# Altium schematic sheet ESP32.SchDoc(ESP32)
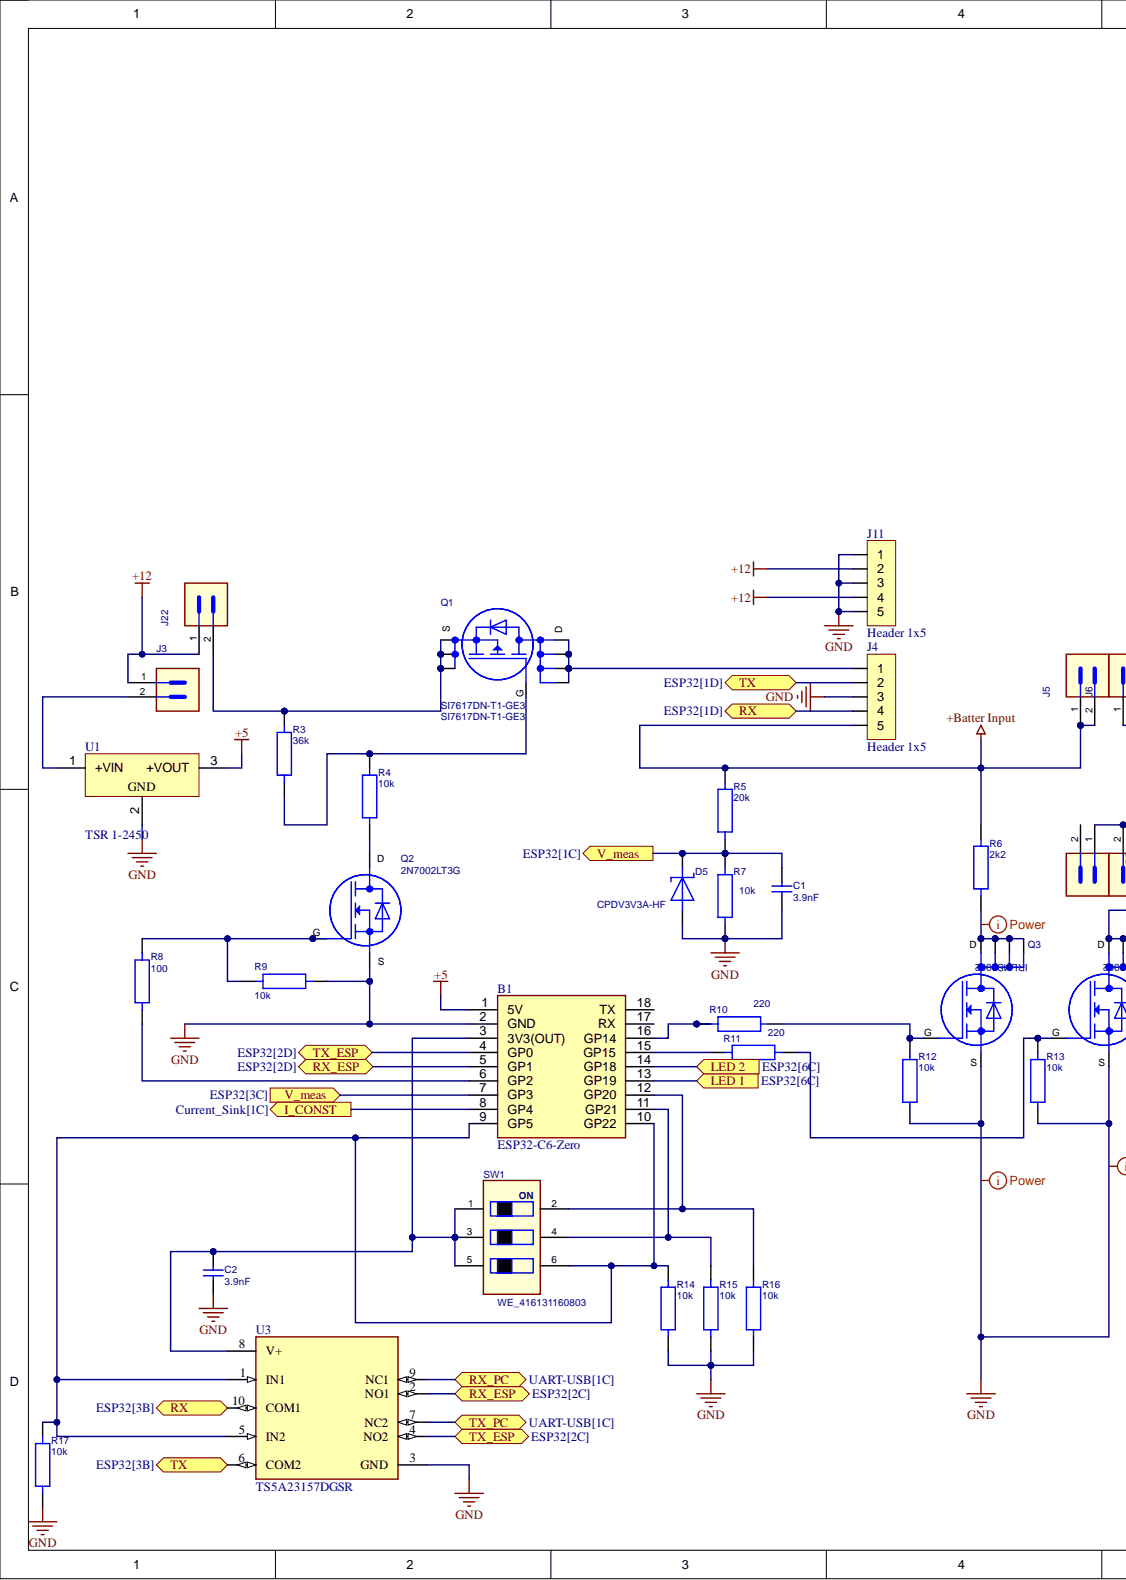
[diagram p0: ESP32.SchDoc, left]
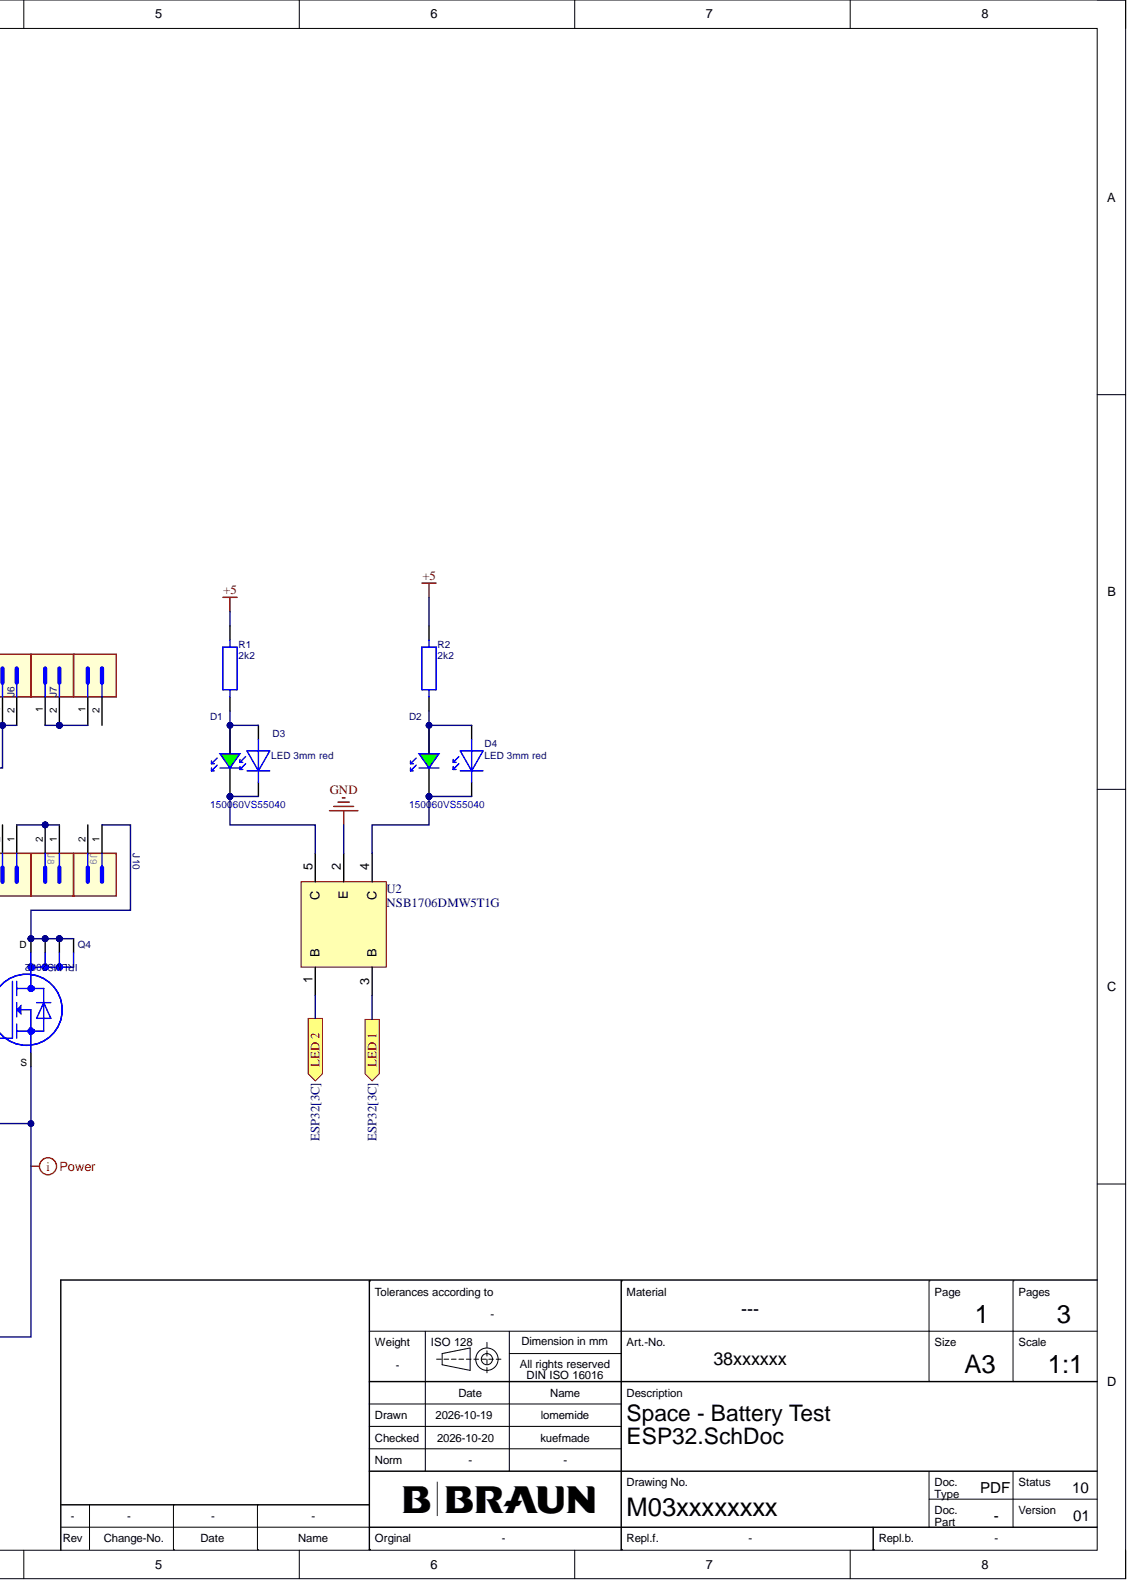
[diagram p1: ESP32.SchDoc, right]

## Parts
B1  ESP32-C6-Zero  [ESP32C6Zero]
C1  3.9nF  [CAP0805H1520]
C2  3.9nF  [CAP0805H1520]
D1  150060VS55040  [LEDC160X75X41L40-green(WE)]
D2  150060VS55040  [LEDC160X75X41L40-green(WE)]
D3  LED 3mm red  Category: LED  Package / Case: 3mm  [LED_THT_3mm]
D4  LED 3mm red  Category: LED  Package / Case: 3mm  [LED_THT_3mm]
D5  CPDV3V3A-HF  [SOD882]
J3  5-825433-0_2/50  [PIN_HEADER_2_54mm_1_1mm_1x2]  (value not drawn)
J4  Header 1x5  [Header 1x5 2.54mm]
J5  5-825433-0_2/50  [PIN_HEADER_2_54mm_1_1mm_1x2]  (value not drawn)
J6  5-825433-0_2/50  [PIN_HEADER_2_54mm_1_1mm_1x2]  (value not drawn)
J7  5-825433-0_2/50  [PIN_HEADER_2_54mm_1_1mm_1x2]  (value not drawn)
J8  5-825433-0_2/50  [PIN_HEADER_2_54mm_1_1mm_1x2]  (value not drawn)
J9  5-825433-0_2/50  [PIN_HEADER_2_54mm_1_1mm_1x2]  (value not drawn)
J10  5-825433-0_2/50  [PIN_HEADER_2_54mm_1_1mm_1x2]  (value not drawn)
J11  Header 1x5  [Header 1x5 2.54mm]
J22  5-825433-0_2/50  [PIN_HEADER_2_54mm_1_1mm_1x2]  (value not drawn)
Q1  SI7617DN-T1-GE3  [PowerPAK1212-8]
Q2  2N7002LT3G  [SOT23]
Q3  IRLMS2002  [SOT23-6]
Q4  IRLMS2002  [SOT23-6]
R1  2k2  [RES0805MD]
R2  2k2  [RES0805MD]
R3  36k  [RES0805MD]
R4  10k  [RES0805MD]
R5  20k  [RES0805MD]
R6  2k2  [RES0805MD]
R7  10k  [RES0805MD]
R8  100  [RES0805MD]
R9  10k  [RES0805MD]
R10  220  [RES0805MD]
R11  220  [RES0805MD]
R12  10k  [RES0805MD]
R13  10k  [RES0805MD]
R14  10k  [RES0805MD]
R15  10k  [RES0805MD]
R16  10k  [RES0805MD]
R17  10k  [RES0805MD]
SW1  WE_416131160803  [WE_416131160803]
U1  TSR 1-2450  [TRACO-SIP-3_V]
U2  NSB1706DMW5T1G  [ONSC-SC70-5-419A-02_V]
U3  TS5A23157DGSR  [FP-DGS0010A-IPC_C]

## Ports
I_CONST, LED 1, LED 2, RX, RX_ESP, RX_PC, TX, TX_ESP, TX_PC, V_meas

## Nets
+5: B1.1(5V) R1.2 R2.2 U1.3
+12: J3.1 J11.2 J11.4 J22.1
+Batter Input: J4.5 J5.1 J5.2 R5.2 R6.1
GND: B1.2(GND) C1.1 C2.1 D5.2 J4.3 J11.1 J11.3 J11.5 Q2.2 Q3.4 Q4.4 R7.1 R9.1 R12.1 R13.1 R14.2 R15.2 R16.2 R17.2 U1.2 U2.2(E) U3.3(GND)
I_CONST: B1.8(GP4) ; port I_CONST
LED 1: B1.13(GP19) U2.3(B) ; port LED 1
LED 2: B1.14(GP18) U2.1(B) ; port LED 2
RX: J4.4 U3.10(COM1) ; port RX
RX_ESP: B1.5(GP1) U3.2(NO1) ; port RX_ESP
RX_PC: U3.9(NC1) ; port RX_PC
TX: J4.2 U3.6(COM2) ; port TX
TX_ESP: B1.4(GP0) U3.4(NO2) ; port TX_ESP
TX_PC: U3.7(NC2) ; port TX_PC
V_meas: B1.7(GP3) C1.2 D5.1 R5.1 R7.2 ; port V_meas
NetB1_3: B1.3(3V3(OUT)) C2.2 SW1.1 SW1.3 SW1.5 U3.8(V+)
NetB1_6: B1.6(GP2) R8.1
NetB1_9: B1.9(GP5) B1.10(GP22) R14.1 R17.1 SW1.6 U3.1(IN1) U3.5(IN2)
NetB1_11: B1.11(GP21) R15.1 SW1.4
NetB1_12: B1.12(GP20) R16.1 SW1.2
NetB1_15: B1.15(GP15) R11.1
NetB1_16: B1.16(GP14) R10.1
NetD1_1: D1.1 D3.2 U2.5(C)
NetD1_2: D1.2 D3.1 R1.1
NetD2_1: D2.1 D4.2 U2.4(C)
NetD2_2: D2.2 D4.1 R2.1
NetJ3_2: J3.2 U1.1
NetJ4_1: J4.1 Q1.5 Q1.6 Q1.7 Q1.8
NetJ6_1: J6.1 J6.2 J7.1
NetJ8_1: J8.1 J9.1 J9.2
NetJ10_1: J10.1 Q4.1 Q4.2 Q4.5 Q4.6
NetJ22_2: J22.2 Q1.1 Q1.2 Q1.3 R3.2
NetQ1_4: Q1.4 R3.1 R4.1
NetQ2_1: Q2.1 R8.2 R9.2
NetQ2_3: Q2.3 R4.2
NetQ3_1: Q3.1 Q3.2 Q3.5 Q3.6 R6.2
NetQ3_3: Q3.3 R10.2 R12.2
NetQ4_3: Q4.3 R11.2 R13.2

Not connected: B1 17(RX) 18(TX); J7 2; J8 2; J10 2

## Library data of the parts
B1 = ESP32-C6-Zero: ESP32-C6 Mini Development Board, Based on ESP32-C6FH4, Dual Processors, 160MHz Running Frequency, 2.4GHz WiFi 6 & Bluetooth 5, ESP32 Development Board; Manufacturer_Name: Waveshare; Geometry.Height: 5.5mm; Component Kind: Standard; Datasheet Link: https://www.waveshare.com/esp32-c6-zero.htm; Library Name: ESP32-C6-Zero.SchLib; Manufacturer_Part_Number: ESP32-C6-Zero
C1 C2 = UID-1DC189D77836E3ADB330: CAP 3.9nF 5% C0G 50V; Manufacturer: KYOCERA AVX; Manufacturer Part Number: 08055A392JAT2A; SAP-Partnumber: 35031280; Compliance: RoHS2; Database Table Name: Capacitors; Library Status: Production; Reviewer: hoersede; Temperature - Rated: -55 °C to +125 °C; Category: Ceramic; Component Kind: Standard; ComponentLink1Description: Datasheet; ComponentLink1URL: https://code.bbraun.io/BA-RD-EE/altium-partlib/raw/main/components/datasheets/35031280_SMD_KOND-KER_3,9NF_5Proz_50V_C0G_0805/AVX_datasheet_C0GNP0-Dielectric.pdf; ComponentLink2Description: Compliance Certificate; ComponentLink2URL: https://code.bbraun.io/BA-RD-EE/altium-partlib/raw/main/components/datasheets/35031280_SMD_KOND-KER_3,9NF_5Proz_50V_C0G_0805/AVX_datasheet_C0GNP0-Dielectric.pdf; ComponentLink3Description: Datasheet Folder; ComponentLink3URL: https://code.bbraun.io/BA-RD-EE/altium-partlib/raw/main/components/datasheets/35031280_SMD_KOND-KER_3,9NF_5Proz_50V_C0G_0805/; ComponentLink4Description: Data Sheet Library; ComponentLink4URL: http://ais.development.bbraun.com/dsl/35031280; Creation Date: 22.05.2023; Last Updated: 22.05.2023; Lifecycle Status: Active; Maximum Height: 1.52 mm; Package / Case: 0805; partid: 60; Price@1k: 0,01183 €; Review Date: 07.06.2025; Supplier 1: Mouser; Supplier 2: Digikey; Supplier 3: RS Components; Supplier 4: Farnell; Technology: SMT; Tolerance: 5%; Type: C0G; uid: UID-1DC189D77836E3ADB330; Value: 3.9nF; Voltage - Rated: 50V; Creator: weirmade; Library Name: BBM-partlib-official.DbLib
D1 D2 = UID-108CCBCADD5F52F6FAD1: Led Green 0603 SMD; Manufacturer: Würth Elektronik; SAP-Partnumber: 35146000; Compliance: RoHS; Current Rated: 20mA; Database Table Name: All; Library Status: Prototype; Remark: NGX; Reviewer: hoersede; Temperature - Rated: -40 °C to +85 °C; Voltage - Rated: 2.4V; Category: LED; Component Kind: Standard; ComponentLink1Description: Datasheet; ComponentLink1URL: https://code.bbraun.io/BA-RD-EE/altium-partlib/raw/main/components/datasheets/35146000_SMD_LED-Green_0603/35146000_Wuerth_150060VS55040_Datasheet.pdf; ComponentLink2Description: Compliance Certificate; ComponentLink2URL: https://code.bbraun.io/BA-RD-EE/altium-partlib/raw/main/components/datasheets/35146000_SMD_LED-Green_0603/35146000_Wuerth_150060VS55040_ROHS_REACH.pdf; ComponentLink3Description: Datasheet Folder; ComponentLink3URL: https://code.bbraun.io/BA-RD-EE/altium-partlib/raw/main/components/datasheets/35146000_SMD_LED-Green_0603/; ComponentLink4Description: Data Sheet Library; ComponentLink4URL: http://ais.development.bbraun.com/dsl/35146000; Creation Date: 21.05.2022; Last Updated: 15.01.2026; Lifecycle Status: Active; Manufacturer Part Number: 150060VS55040; Maximum Height: 0.5 mm; Package / Case: 0603; partid: 61; Price@1k: 0,033 €; Review Date: 08.06.2025; Supplier 1: Mouser; Supplier 2: Digikey; Supplier 3: RS Components; Supplier 4: Farnell; Supplier Part Number 1: 710-150060VS55040; Supplier Part Number 2: 732-12017-2-ND; Supplier Part Number 3: 1766157; Supplier Part Number 4: 2900765RL; Technology: SMT; uid: UID-108CCBCADD5F52F6FAD1; Creator: GEG DF,GK; Library Name: BBM-partlib-official.DbLib
D3 D4 = UID-E12BBC48C07D2EA352E9: LED Red, 625 nm, GaAsP/GaP, THT, 3mm; Manufacturer: TruComponents; Manufacturer Part Number: TC-9510472; Current Rated: 20 mA; Database Table Name: All; Library Status: New; Remark: EPG; Temperature - Rated: -40 °C to + 85 °C; Voltage - Rated: 5V; BBPUC-Partnumber: -; Component Kind: Standard; ComponentLink1Description: Datasheet; ComponentLink1URL: https://code.bbraun.io/BA-RD-EE/altium-partlib/raw/main/components/datasheets/UID-E12BBC48C07D2EA352E9_LED_3mm_Red_THT/3mm_LED_Datasheet.pdf; ComponentLink2Description: Compliance Certificate; ComponentLink3Description: Datasheet Folder; ComponentLink3URL: https://code.bbraun.io/BA-RD-EE/altium-partlib/raw/main/components/datasheets/UID-E12BBC48C07D2EA352E9_LED_3mm_Red_THT/; ComponentLink4Description: Data Sheet Library; Creation Date: 22.04.2026; Lifecycle Status: Active; Maximum Height: 6.3 mm; partid: 106; Price@1k: 0,18 €; Supplier 1: Conrad; Supplier 2: Digikey; Supplier 3: RS Components; Supplier 4: Farnell; Supplier Part Number 1: 2377618 - 62; Technology: THT; uid: UID-E12BBC48C07D2EA352E9; Creator: palmfrde; Library Name: BBM-partlib-official.DbLib
D5 = UID-3D03554C99A7E31313F8: Unidirectional ESD protection diode; BBPUC-Partnumber: 2838.332; Manufacturer: Nexperia; Manufacturer Part Number: PESD3V3S1UL; SAP-Partnumber: 35140169; Compliance: RoHS2; Current Rated: 15A; Database Table Name: All; Library Status: Prototype; Reviewer: hoersede; Temperature - Rated: -55 °C to +150 °C; Voltage - Rated: 3.3V; Category: ESD Diode; Component Kind: Standard; ComponentLink1Description: Datasheet; ComponentLink1URL: https://code.bbraun.io/BA-RD-EE/altium-partlib/raw/main/components/datasheets/35140169_SMD_Diode_ESD_PESD3V3S1UL_SOD882/PESD3V3S1UL.pdf; ComponentLink2Description: Compliance Certificate; ComponentLink2URL: https://code.bbraun.io/BA-RD-EE/altium-partlib/raw/main/components/datasheets/35140169_SMD_Diode_ESD_PESD3V3S1UL_SOD882/Nexperia_-_Statement_on_RoHS_082019.pdf; ComponentLink3Description: Datasheet Folder; ComponentLink3URL: https://code.bbraun.io/BA-RD-EE/altium-partlib/raw/main/components/datasheets/35140169_SMD_Diode_ESD_PESD3V3S1UL_SOD882/; ComponentLink4Description: Data Sheet Library; ComponentLink4URL: http://ais.development.bbraun.com/dsl/35140169; Creation Date: 16.05.2023; Last Updated: 22.06.2023; Lifecycle Status: Active; Maximum Height: 0.5 mm; Package / Case: SOD882; partid: 43; Price@1k: 0,0247 €; Review Date: 08.06.2025; Supplier 1: Mouser; Supplier 2: Digikey; Supplier 3: RS Components; Supplier 4: Farnell; uid: UID-3D03554C99A7E31313F8; Creator: hoersede; Library Name: BBM-partlib-official.DbLib
J3 J5-J10 J22 = UID-C948C1FBE4D127CA7C47: THT Pin Header, 1x2, 2.54mm, stehend; Manufacturer: TE Connectivity; SAP-Partnumber: 33193339; Compliance: RoHS2; Contacts: 2; Current Rated: 5A; Database Table Name: All; Library Status: Prototype; Reviewer: vaupmide; Temperature - Rated: -65 °C to + 105 °C; Voltage - Rated: 750Vrms; Category: Pin Header; Component Kind: Standard; ComponentLink1Description: Datasheet; ComponentLink1URL: https://code.bbraun.io/BA-RD-EE/altium-partlib/raw/main/components/datasheets/33193339_PIN_HEADER_2_54mm_1x50_TE/product-5-825433-0.datasheet.pdf; ComponentLink2Description: Compliance Certificate; ComponentLink2URL: https://code.bbraun.io/BA-RD-EE/altium-partlib/raw/main/components/datasheets/33193339_PIN_HEADER_2_54mm_1x50_TE/product-5-825433-0.datasheet.pdf; ComponentLink3Description: Datasheet Folder; ComponentLink3URL: https://code.bbraun.io/BA-RD-EE/altium-partlib/raw/main/components/datasheets/33193339_PIN_HEADER_2_54mm_1x50_TE; ComponentLink4Description: Data Sheet Library; ComponentLink4URL: http://ais.development.bbraun.com/dsl/33193339; Creation Date: 09.02.2023; Last Updated: 22.06.2023; Lifecycle Status: Active; Manufacturer Part Number: 5-825433-0; Maximum Height: 8.54 mm; partid: 27; Price@1k: 1,65 €; Review Date: 12.06.2024; Supplier 1: Mouser; Supplier 2: Digikey; Supplier 3: RS Components; Supplier 4: Farnell; uid: UID-C948C1FBE4D127CA7C47; Creator: sifngu01; Library Name: BBM-partlib-official.DbLib
J4 J11 = CMP-002-00275-1: Header 1x5 2.54mm; Component Kind: Standard; Design Item ID: Header 1x5; Source: Header.SchLib; Library Name: Discover Tour
Q1 = UID-FE97C754B092DB379145: FET P-CH; Manufacturer: Vishay; SAP-Partnumber: 35140024; Compliance: RoHS3; Current - Rated: 35 A; Database Table Name: Transistors; Library Status: Prototype; RDS-on: 0.0123R; Reviewer: vaupmide; Temperature - Rated: -55 °C to +150 °C; Voltage - Rated: 30V; Category: FET p-Ch; Component Kind: Standard; ComponentLink1Description: Datasheet; ComponentLink1URL: https://code.bbraun.io/BA-RD-EE/altium-partlib/raw/main/components/datasheets/35140024_SMD_Transistor_P-Channel_Si7617DN/Datasheet_SI7617DN.pdf; ComponentLink2Description: Compliance Certificate; ComponentLink2URL: https://code.bbraun.io/BA-RD-EE/altium-partlib/raw/main/components/datasheets/35140024_SMD_Transistor_P-Channel_Si7617DN/Compliance/VISH-E-A0015053004-1.pdf; ComponentLink3Description: Datasheet Folder; ComponentLink3URL: https://code.bbraun.io/BA-RD-EE/altium-partlib/raw/main/components/datasheets/35140024_SMD_Transistor_P-Channel_Si7617DN/; ComponentLink4Description: Data Sheet Library; ComponentLink4URL: http://ais.development.bbraun.com/dsl/35140024; Creation Date: 04.08.2023; Last Updated: 28.11.2023; Lifecycle Status: Active; Manufacturer Part Number: SI7617DN-T1-GE3; Maximum Height: 1.17 mm; Package / Case: PowerPAK1212-8; partid: 22; Price@1k: 0,2450 €; Review Date: 08.07.2024; Supplier 1: Mouser; Supplier 2: Digikey; Supplier 3: RS Components; Supplier 4: Farnell; Supplier Part Number 1: 781-SI7617DN-GE3; Supplier Part Number 2: SI7617DN-T1-GE3TR-ND; Technology: SMT; uid: UID-FE97C754B092DB379145; Creator: mochalde; Library Name: BBM-partlib-official.DbLib
Q2 = UID-746324AF67844B7863CB: N-Channel MOSFET 60V 115m, SMD SOT23-3; Manufacturer: onsemi; SAP-Partnumber: 35140038; Compliance: RoHS; Current - Rated: 115 mA; Database Table Name: Transistors; Library Status: Prototype; RDS-on: 7R5; Remark: NGX; Reviewer: vaupmide; Temperature - Rated: -55 °C to +150 °C; Category: FET n-Ch; Component Kind: Standard; ComponentLink1Description: Datasheet; ComponentLink1URL: https://code.bbraun.io/BA-RD-EE/altium-partlib/raw/main/components/datasheets/35140038_SMD_MOSFET-N-Chanel_2N7002L_SOT23/Onsemi_2N7002LT3G.PDF; ComponentLink2Description: Compliance Certificate; ComponentLink2URL: https://code.bbraun.io/BA-RD-EE/altium-partlib/raw/main/components/datasheets/35140038_SMD_MOSFET-N-Chanel_2N7002L_SOT23/Compliance/RoHS-Compliance_2N7002LT3G.png; ComponentLink3Description: Datasheet Folder; ComponentLink3URL: https://code.bbraun.io/BA-RD-EE/altium-partlib/raw/main/components/datasheets/35140038_SMD_MOSFET-N-Chanel_2N7002L_SOT23/; ComponentLink4Description: Data Sheet Library; ComponentLink4URL: http://ais.development.bbraun.com/dsl/35140038; Creation Date: 22.04.2022; Last Updated: 10.01.2024; Lifecycle Status: Active; Manufacturer Part Number: 2N7002LT3G; Maximum Height: 1.1 mm; Package / Case: SOT-23; partid: 17; Price@1k: 0,235 €; Review Date: 08.07.2024; Supplier 1: Mouser; Supplier 2: Digikey; Supplier 3: RS Components; Supplier 4: Farnell; Supplier Part Number 1: 863-2N7002LT3G; Supplier Part Number 2: 2N7002LT3GOSTR-ND; Technology: SMT; uid: UID-746324AF67844B7863CB; Voltage - Rated: 60V; Creator: GEG DF,GK; Library Name: BBM-partlib-official.DbLib
Q3 Q4 = UID-A18DAACDD2725A595700: FET N-Ch; Manufacturer: Infineon; Manufacturer Part Number: IRLMS2002TRPBF; SAP-Partnumber: 35140704; Compliance: RoHS2; Current Rated: 6.5A; Database Table Name: All; Library Status: Production; RDS-on: 0.03R; Reviewer: hoersede; Temperature - Rated: -55 °C to +150 °C; Voltage - Rated: 20V; Category: FET n-Ch; Component Kind: Standard; ComponentLink1Description: Datasheet; ComponentLink1URL: https://code.bbraun.io/BA-RD-EE/altium-partlib/raw/main/components/datasheets/35140704_SMD_TRANSISTOR_N-KANAL_IRLMS2002_SOT-23/Infineon_datasheet_IRLMS2002-v01_01.pdf; ComponentLink2Description: Compliance Certificate; ComponentLink2URL: https://code.bbraun.io/BA-RD-EE/altium-partlib/raw/main/components/datasheets/35140704_SMD_TRANSISTOR_N-KANAL_IRLMS2002_SOT-23/Infineon_datasheet_IRLMS2002-v01_01.pdf; ComponentLink3Description: Datasheet Folder; ComponentLink3URL: https://code.bbraun.io/BA-RD-EE/altium-partlib/raw/main/components/datasheets/35140704_SMD_TRANSISTOR_N-KANAL_IRLMS2002_SOT-23/; ComponentLink4Description: Data Sheet Library; ComponentLink4URL: http://ais.development.bbraun.com/dsl/35140704; Creation Date: 18.08.2022; Lifecycle Status: Active; Maximum Height: 1.45 mm; Package / Case: SOT23; partid: 7; Price@1k: 0,0751 €; Review Date: 07.06.2025; Supplier 1: Mouser; Supplier 2: Digikey; Supplier 3: RS Components; Supplier 4: Farnell; uid: UID-A18DAACDD2725A595700; Creator: weirmade; Library Name: BBM-partlib-official.DbLib
R1-R17 = UID-0F2DF2513351442B7CD8: RES 2K2 1% 125mW 0805; Manufacturer: Yageo; Manufacturer Part Number: RC0805FR-132K2L; SAP-Partnumber: 35110490; Compliance: RoHS; Database Table Name: All; Library Status: New; Remark: NGX; Reviewer: hoersede; Temperature - Rated: -55 °C to +155 °C; Temperature Coefficient: TC100; Voltage - Rated: 150V; Component Kind: Standard; ComponentLink1Description: Datasheet; ComponentLink1URL: https://code.bbraun.io/BA-RD-EE/altium-partlib/raw/main/components/datasheets/35110490_SMD_Widerstand_2K2_1Proz_0805/Yageo_RC0805FR-132K2L.pdf; ComponentLink2Description: Compliance Certificate; ComponentLink2URL: https://code.bbraun.io/BA-RD-EE/altium-partlib/raw/main/components/datasheets/35110490_SMD_Widerstand_2K2_1Proz_0805/Yageo_RC0805FR-132K2L.pdf; ComponentLink3Description: Datasheet Folder; ComponentLink3URL: https://code.bbraun.io/BA-RD-EE/altium-partlib/raw/main/components/datasheets/35110490_SMD_Widerstand_2K2_1Proz_0805/; ComponentLink4Description: Data Sheet Library; ComponentLink4URL: http://ais.development.bbraun.com/dsl/35110490; Creation Date: 22.04.2022; Last Updated: 22.06.2023; Lifecycle Status: Active; Maximum Height: 0.6 mm; Package / Case: 0805; partid: 156; Power - Rated: 125mW; Price@1k: 0,0075 €; Review Date: 10.03.2026; Supplier 1: Mouser; Supplier 2: Digikey; Supplier 3: RS Components; Supplier 4: Farnell; Supplier Part Number 1: 603-RC0805FR-132K2L; Supplier Part Number 2: 13-RC0805FR-132K2LTR-ND; Technology: SMT; Tolerance: 1%; Type: Thick Film; uid: UID-0F2DF2513351442B7CD8; Value: 2k2; Creator: GEG DF,GK; Library Name: BBM-partlib-official.DbLib
SW1 = UID-1051BDF3C131CE8C09EA: SMD DIP-Switch tripple 1.27mm pitch; Manufacturer: Würth Elektronik; Compliance: RoHS; Database Table Name: All; Temperature - Rated: -40 °C to +85 °C; Category: DIP Switch; Component Kind: Standard; ComponentLink1Description: Datasheet; ComponentLink1URL: https://code.bbraun.io/BA-RD-EE/altium-partlib/raw/main/components/datasheets/UID-1051BDF3C131CE8C09EA_DIP-Switch_Wuerth_416131160803/Wuerth_416131160803.pdf; ComponentLink2Description: Compliance Certificate; ComponentLink2URL: https://code.bbraun.io/BA-RD-EE/altium-partlib/raw/main/components/datasheets/UID-1051BDF3C131CE8C09EA_DIP-Switch_Wuerth_416131160803/Wuerth_416131160803.pdf; ComponentLink3Description: Datasheet Folder; ComponentLink3URL: https://code.bbraun.io/BA-RD-EE/altium-partlib/raw/main/components/datasheets/UID-1051BDF3C131CE8C09EA_DIP-Switch_Wuerth_416131160803/; ComponentLink4Description: Data Sheet Library; Creation Date: 11.02.2025; Manufacturer Part Number: 416131160803; Maximum Height: 2.65 mm; partid: 30; Price@1k: 1,76 €; Supplier 1: Mouser; Supplier 2: Digikey; Supplier 3: RS Components; Supplier 4: Farnell; Supplier Part Number 1: 710-416131160803; Supplier Part Number 2: 732-416131160803TR-ND; Supplier Part Number 3: 254-1753; Technology: SMT; uid: UID-1051BDF3C131CE8C09EA; Creator: ostemcde; Library Name: BBM-partlib-official.DbLib
U1 = CMP-2000-05427-1: DC/DC converter, 5 VDC, 1000 mA, -40 to 85 degC, 3-Pin SIP, RoHS; Manufacturer: Tracopower; Approvals & Standards: IEC,UL; DC / DC Converter Mounting: ThroughHole; DC / DC Converter Output Type: Fixed; Input Voltage DC Max: 36V; Input Voltage DC Min: 6.5V; No. of Outputs: 1Output; Output Current Max: 1A; Output Power Max: 5W; Output Voltage Nom.: 5V; Product Range: TSR-1Series; Component Kind: Standard; Datasheet URL: https://datasheet.ciiva.com/6815/tsr1-6815641.pdf; Datasheet Version: Jan-15; DC / DC Converter Type: SIP; Depth: 11.7mm; Height: 10.2mm; Library Name: Altium Content Vault; Manufacturer URL: http://www.tracopower.com/home; Package Description: 3-Pin Single In-line Package, Body 11.7 x 7.6 mm, Pitch 2.54 mm; Package Reference: SIP-3; Width: 7.6mm
U2 = CMP-0630-00174-1: Dual Bias Resistor Transistors, NPN Silicon Surface Mount Transistor, 5-Pin SC70, Pb-Free, Tape and Reel; Manufacturer: On Semiconductor; hFE Min: 80; IC Continuous (A): 0.1; Polarity: Dual NPN; V(BR)CEO Min (V): 50; Component Kind: Standard; ComponentLink1Description: Manufacturer URL; ComponentLink1URL: http://www.onsemi.com/; ComponentLink2Description: Datasheet; ComponentLink2URL: http://www.onsemi.cn/pub_link/Collateral/NSB1706DMW5T1-D.PDF; ComponentLink3Description: Package Specification; ComponentLink3URL: http://www.onsemi.cn/pub_link/Collateral/419A-02.PDF; DatasheetVersion: Rev. 5; Library Name: Altium Content Vault; Mounting Technology: Surface Mount; PackageDescription: 5-Pin Small Outline Transistor, Body 2 x 1.25 mm, Pitch 0.65 mm; PackageReference: SC70-5-419A-02; PackageVersion: Rev. L, 01/2013; Packing: Tape and Reel; PartNumber: NSB1706DMW5T1G; R1/R2 Typ: 0.1; RoHS: TRUE
U3 = CMP-04892-000058-1: IC SWITCH DUAL SPDT 10MSOP; Bandwidth: 220MHz; High Level Output Current: 50mA; Max Supply Current: 1uA; Max Supply Voltage: 5.5V; Min Supply Voltage: 1.8V; Number of Bits: 2; Number of Outputs: 4; On-State Resistance: 10R; Polarity: Non-Inverting; Supply Type: Single; Turn-Off Delay Time: 3.8ns; Turn-On Delay Time: 5.7ns; Case/Package: VSSOP; Component Kind: Standard; Contact Plating: Gold; Height: 1.02mm; Lead Free: Lead Free; Length: 3mm; Library Name: Altium Content Vault; Low Level Output Current: 50mA; Max Operating Temperature: 85°C; Min Operating Temperature: -40°C; Mount: Surface Mount; Number of Channels: 2; Number of Inputs: 2; Number of Pins: 10; Operating Supply Current: 1uA; Package Quantity: 1; Packaging: Tape and Reel; Radiation Hardening: No; Series: -; Throw Configuration: SPDT; Weight: 0.000663oz; Width: 3mm
